# Altium schematic sheet [06] - IO_Ports.SchDoc
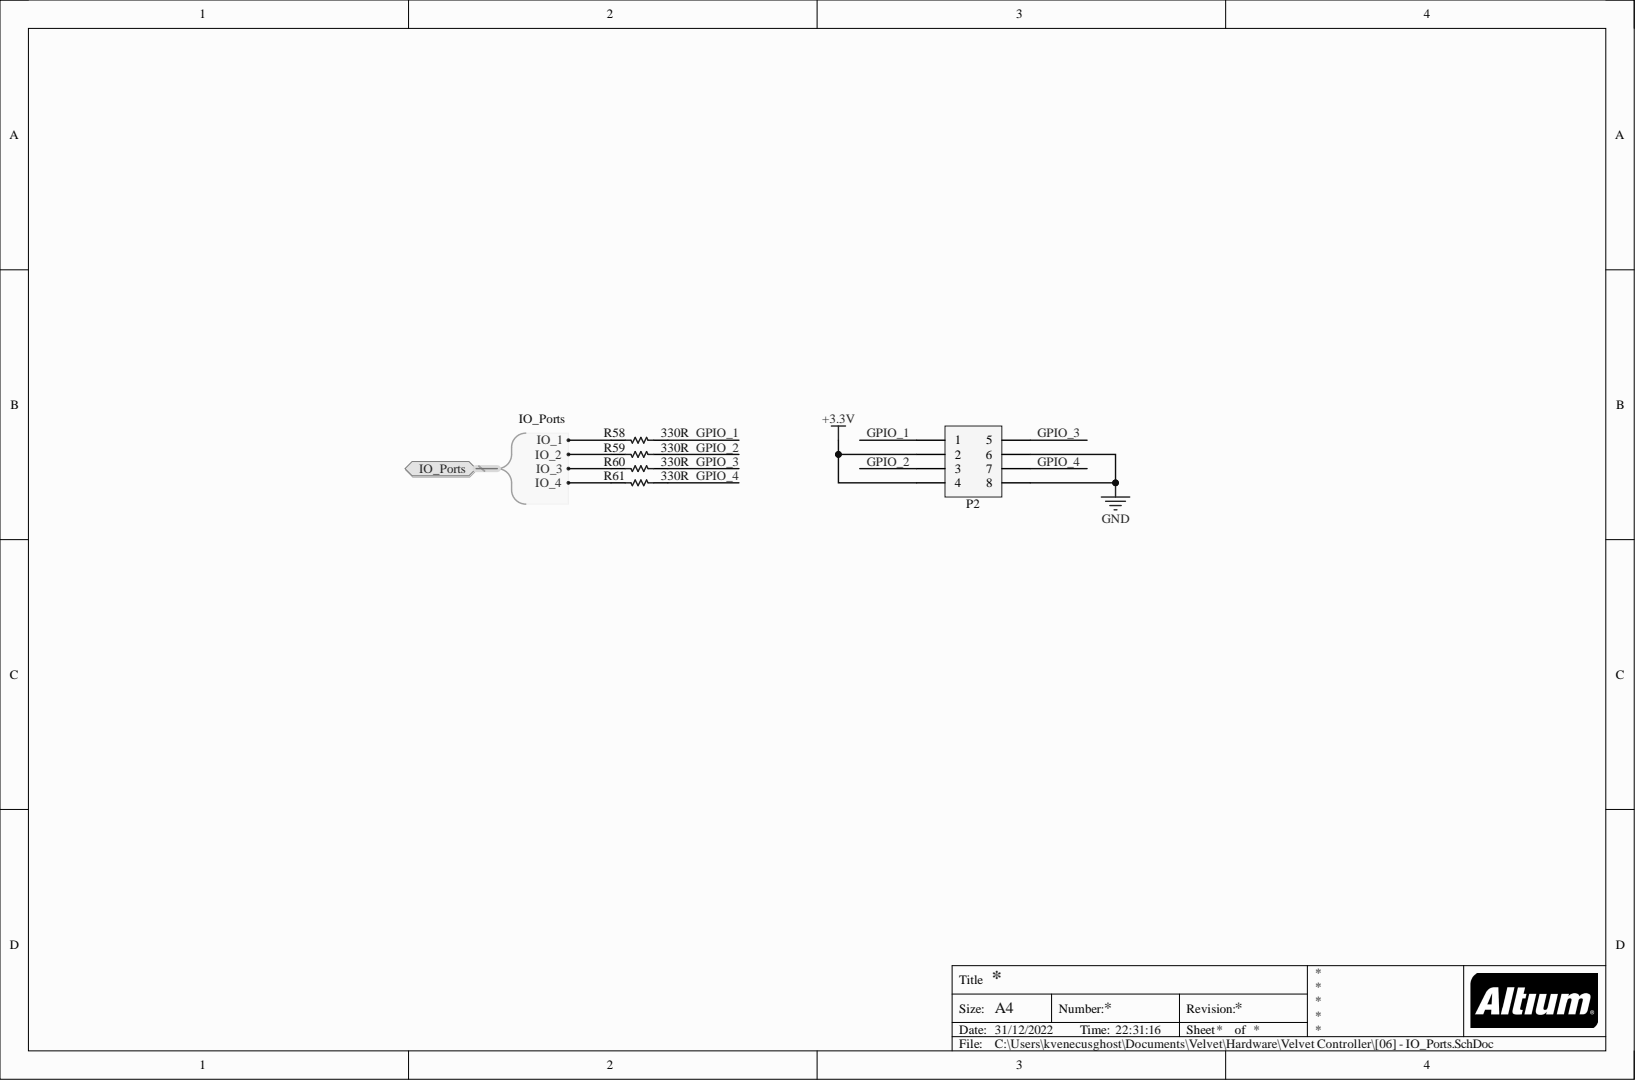
[diagram p0: [06] - IO_Ports.SchDoc]

## Parts
P2  Header 4X2A  [CON: PLD-2*4]  (value not drawn)
R58  Resistance: 330R  [0603_R]  (hidden comment: Res1)
R59  Resistance: 330R  [0603_R]  (hidden comment: Res1)
R60  Resistance: 330R  [0603_R]  (hidden comment: Res1)
R61  Resistance: 330R  [0603_R]  (hidden comment: Res1)

## Ports
IO_Ports, IO_Ports.IO_1, IO_Ports.IO_2, IO_Ports.IO_3, IO_Ports.IO_4

## Nets
+3.3V: P2.2 P2.4
GND: P2.6 P2.8
GPIO_1: P2.1 R58.2
GPIO_2: P2.3 R59.2
GPIO_3: P2.5 R60.2
GPIO_4: P2.7 R61.2
NetR58_1: R58.1 ; port IO_Ports
NetR59_1: R59.1 ; port IO_Ports
NetR60_1: R60.1 ; port IO_Ports
NetR61_1: R61.1 ; port IO_Ports

## Library data of the parts
P2 = Header 4X2A: Header, 4-Pin, Dual row; Component Kind: Standard; LatestRevisionDate: 17-Jul-2002; LatestRevisionNote: Re-released for DXP Platform.; Library Name: Miscellaneous Connectors.IntLib; Signal Integrity: Connector; Publisher: Altium Limited
R58-R61 = 1: Chip Resistor; Manufacturer: Panasonic; Manufacturer Part Number: ERJ-3EKF3300V; Database Table Name: Resistors$; Max Power Dissipation: 100mW; Temperature Coefficient: 100ppm/°C; Tolerance: 1%; Voltage Rating: 75V; Case Code (Imperial): 0603; Case Code (Metric): 1608; Case/Package: 0603; Component Kind: Standard; ComponentLink1Description: http://industrial.panasonic.com/www-cgi/jvcr13pz.cgi?E+PZ+3+AOA0002+ERJ6ENF3000V+7+WW; ComponentLink1URL: http://industrial.panasonic.com/www-cgi/jvcr13pz.cgi?E+PZ+3+AOA0002+ERJ6ENF3000V+7+WW; ComponentLink2Description: https://datasheet.ciiva.com/7886/aoa0000ce2-7886729.pdf; ComponentLink2URL: https://datasheet.ciiva.com/7886/aoa0000ce2-7886729.pdf; ComponentLink3Description: https://datasheet.ciiva.com/48531/aoa0000ce2-48531001.pdf; ComponentLink3URL: https://datasheet.ciiva.com/48531/aoa0000ce2-48531001.pdf; ComponentLink4Description: https://datasheet.ciiva.com/22721/426256-22721099.pdf; ComponentLink4URL: https://datasheet.ciiva.com/22721/426256-22721099.pdf; ComponentLink5Description: https://datasheet.ciiva.com/46373/1678782-46373899.pdf; ComponentLink5URL: https://datasheet.ciiva.com/46373/1678782-46373899.pdf; ComponentLink6Description: https://datasheet.ciiva.com/9117/aoa0000ce2-9117132.pdf; ComponentLink6URL: https://datasheet.ciiva.com/9117/aoa0000ce2-9117132.pdf; ComponentLink7Description: https://datasheet.ciiva.com/46373/1678782-46373900.pdf; ComponentLink7URL: https://datasheet.ciiva.com/46373/1678782-46373900.pdf; Composition: Thick Film; Contact Plating: Tin; Depth: 0.8mm; Features: Automotive AEC-Q200; Height: 0.022inch; Lead Free: Lead Free; Length: 1.6mm; Library Name: Altium_Library.DbLib; Max Operating Temperature: 155°C; Military Standard: Not; Min Operating Temperature: -55°C; Mount: Surface Mount; Number of Pins: 2; Number of Terminations: 2; Package Quantity: 1; Packaging: Tape and Reel; Power Rating: 100mW; Pricing 1: 1=0.1, 10=0.079, 100=0.0323, 1000=0.01449, 2500=0.01258 (USD); Radiation Hardening: No; REACH SVHC: No SVHC; Stock 1: 924496; Supplier 1: Digi-Key; Supplier Part Number 1: P330HDKR-ND; Termination: SMD/SMT; Voltage Rating (DC): 75V; Weight: 7.1E-05oz; Width: 0.8mm
